# Altium schematic sheet Caja_de_Botones.SchDoc(Caja_de_Botones)
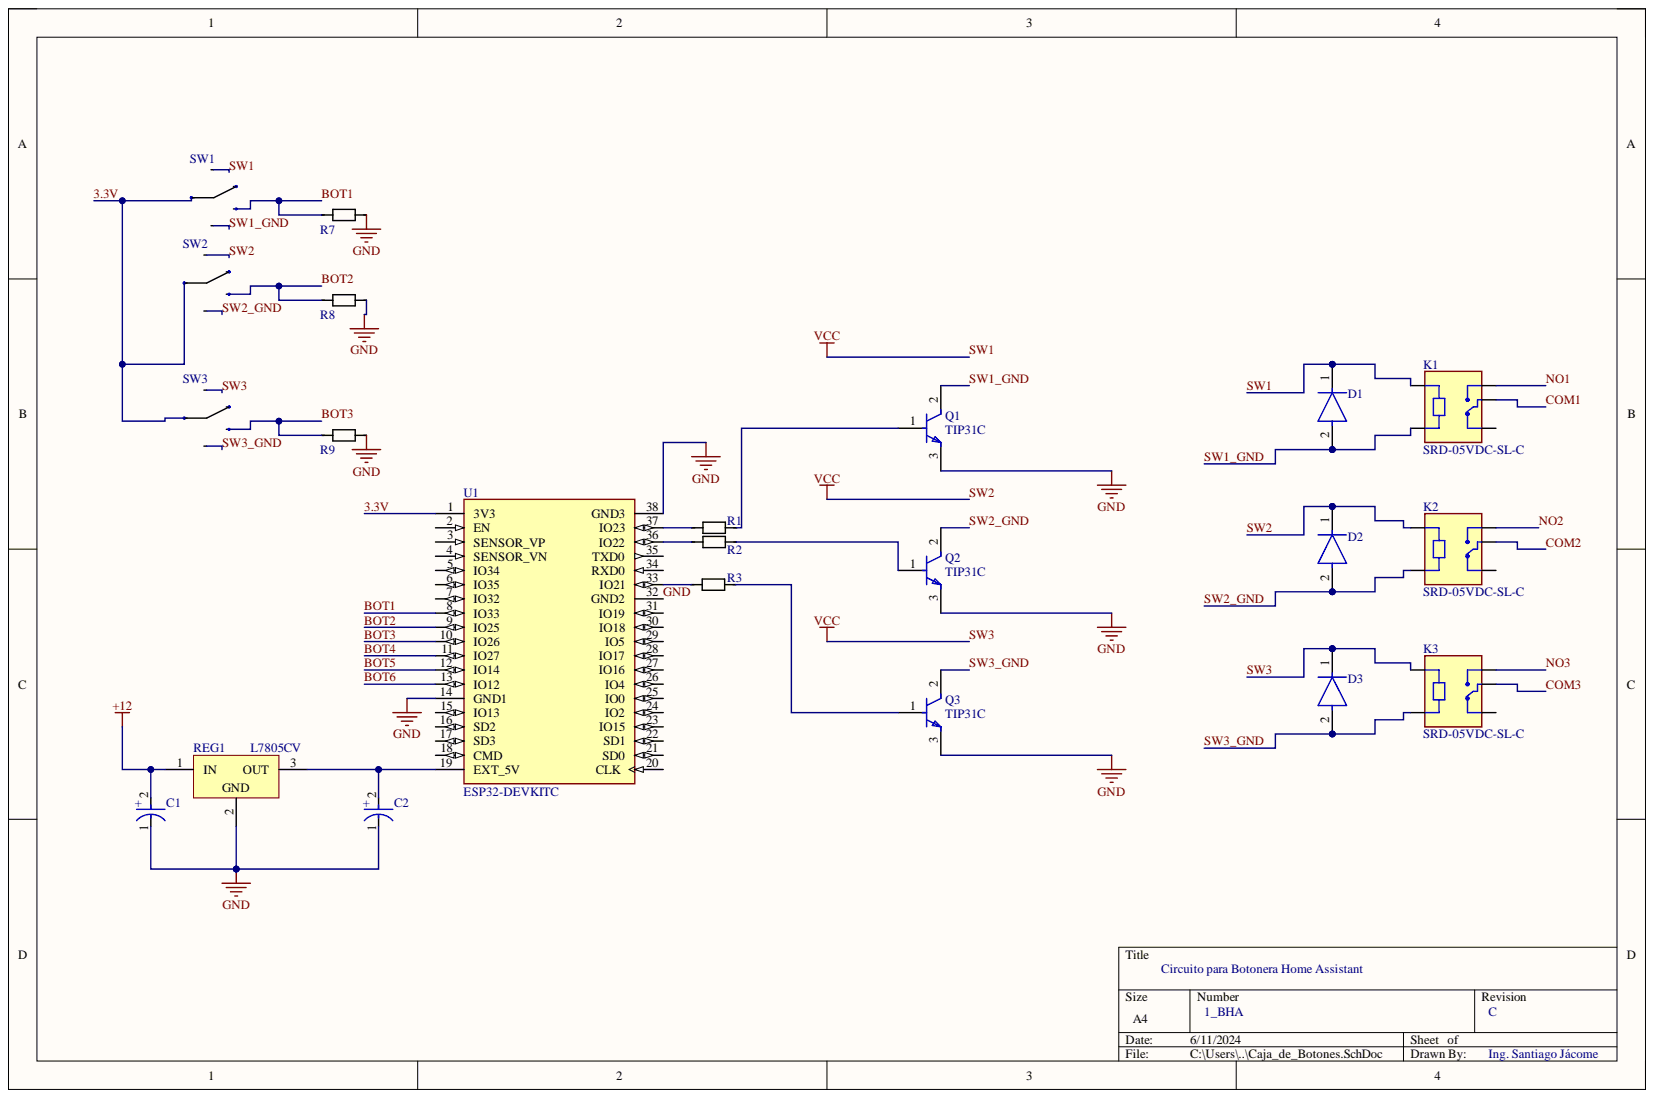
[diagram p0: Caja_de_Botones.SchDoc]

## Parts
C1  EEAGA1V470  [FP-RAD-TH-D_8_0_5-L_7_1-F-MFG]  (value not drawn)
C2  EEAGA1V470  [FP-RAD-TH-D_8_0_5-L_7_1-F-MFG]  (value not drawn)
D1  1N4001-E3/54  [FP-1N4001-E3-54-MFG]  (value not drawn)
D2  1N4001-E3/54  [FP-1N4001-E3-54-MFG]  (value not drawn)
D3  1N4001-E3/54  [FP-1N4001-E3-54-MFG]  (value not drawn)
K1  SRD-05VDC-SL-C  [RELAY_SRD-05VDC-SL-C]
K2  SRD-05VDC-SL-C  [RELAY_SRD-05VDC-SL-C]
K3  SRD-05VDC-SL-C  [RELAY_SRD-05VDC-SL-C]
Q1  TIP31C  [FP-TO-220-P0A0015988-MFG]
Q2  TIP31C  [FP-TO-220-P0A0015988-MFG]
Q3  TIP31C  [FP-TO-220-P0A0015988-MFG]
R1  General 1/4 W Resistor  [Generic 1/4W Metallic Resistor Axial]  (value not drawn)
R2  General 1/4 W Resistor  [Generic 1/4W Metallic Resistor Axial]  (value not drawn)
R3  General 1/4 W Resistor  [Generic 1/4W Metallic Resistor Axial]  (value not drawn)
R7  General 1/4 W Resistor  [Generic 1/4W Metallic Resistor Axial]  (value not drawn)
R8  General 1/4 W Resistor  [Generic 1/4W Metallic Resistor Axial]  (value not drawn)
R9  General 1/4 W Resistor  [Generic 1/4W Metallic Resistor Axial]  (value not drawn)
REG1  L7805CV  [TO220]
SW1  16mm 5 Pin Momentary Button  [16mm Pushbutton 5 Pins]  (value not drawn)
SW2  16mm 5 Pin Momentary Button  [16mm Pushbutton 5 Pins]  (value not drawn)
SW3  16mm 5 Pin Momentary Button  [16mm Pushbutton 5 Pins]  (value not drawn)
U1  ESP32-DEVKITC  [MODULE_ESP32-DEVKITC]

## Nets
+12: C1.2 REG1.1
3.3V: SW1.COM SW2.COM SW3.COM U1.1(3V3)
BOT1: R7.1 SW1.NO U1.8(IO33)
BOT2: R8.1 SW2.NO U1.9(IO25)
BOT3: R9.1 SW3.NO U1.10(IO26)
BOT4: U1.11(IO27)
BOT5: U1.12(IO14)
BOT6: U1.13(IO12)
COM1: K1.COM
COM2: K2.COM
COM3: K3.COM
GND: C1.1 C2.1 Q1.3 Q2.3 Q3.3 R7.2 R8.2 R9.2 REG1.2 U1.14(GND1) U1.32(GND2) U1.38(GND3)
NO1: K1.NO
NO2: K2.NO
NO3: K3.NO
SW1: D1.1 D2.1 D3.1 K1.A1 K2.A1 K3.A1 SW1.LED- SW2.LED- SW3.LED-
SW1_GND: D1.2 K1.A2 Q1.2 SW1.LED+
SW2_GND: D2.2 K2.A2 Q2.2 SW2.LED+
SW3_GND: D3.2 K3.A2 Q3.2 SW3.LED+
NetC2_2: C2.2 REG1.3 U1.19(EXT_5V)
NetQ1_1: Q1.1 R1.2
NetQ2_1: Q2.1 R2.2
NetQ3_1: Q3.1 R3.2
NetR1_1: R1.1 U1.37(IO23)
NetR2_1: R2.1 U1.36(IO22)
NetR3_1: R3.1 U1.33(IO21)

Not connected: K1 NC; K2 NC; K3 NC; SW1 NC; SW2 NC; SW3 NC; U1 2(EN) 3(SENSOR_VP) 4(SENSOR_VN) 5(IO34) 6(IO35) 7(IO32) 15(IO13) 16(SD2) 17(SD3) 18(CMD) 20(CLK) 21(SD0) 22(SD1) 23(IO15) 24(IO2) 25(IO0) 26(IO4) 27(IO16) 28(IO17) 29(IO5) 30(IO18) 31(IO19) 34(RXD0) 35(TXD0)

## Library data of the parts
C1 C2 = CMP-05427-002179-1: Aluminum Electrolytic Capacitors (Radial Lead Type) 47uF ±20% 35V; Lead Diameter: 0.45mm; Lead/Base Style: Straight; Leakage Current: 16.5uA; Life (Hours): 1000Hour; Ripple Current: 96mA; Capacitance: 47uF; Case/Package: Radial; Component Kind: Standard; Construction: RADIAL; Contact Plating: Tin; Diameter: 8mm; Height: 7mm; Height - Seated (Max): 0.276inch; Lead Free: Lead Free; Lead Pitch: 2.5mm; Length: 7mm; Library Name: Altium Content Vault; Max Operating Temperature: 105°C; Min Operating Temperature: -55°C; Mount: Through Hole; Number of Pins: 2; Package Quantity: 200; Packaging: Bulk; Radiation Hardening: No; Reach SVHC Compliant: Yes; Ripple Current (AC): 96mA; RoHS Compliant: Yes; Series: GA; Termination: Radial; Tolerance: 20%; Voltage: 35V; Voltage Rating: 35V; Voltage Rating (DC): 35V
D1-D3 = CMP-02540-000077-1: DIODE GEN PURP 50V 1A DO204AL; Capacitance: 15pF; Element Configuration: Single; Forward Voltage: 1.1V; Max Forward Surge Current (Ifsm): 30A; Max Reverse Current: 5uA; Peak Non-Repetitive Surge Current: 45A; Polarity: Standard; Average Rectified Current: 1A; Case/Package: DO-204AL; Component Kind: Standard; Current: 1A; Current Rating: 1A; Diameter: 2.7mm; Forward Current: 1A; Height: 2.7mm; Lead Free: Lead Free; Length: 0.204inch; Library Name: Altium Content Vault; Max Operating Temperature: 150°C; Max Repetitive Reverse Voltage (Vrrm): 50V; Max Reverse Voltage (DC): 50V; Max Surge Current: 30A; Min Operating Temperature: -50°C; Mount: Through Hole; Number of Pins: 2; Output Current: 1A; Package Quantity: 5500; Packaging: Tape and Reel; Radiation Hardening: No; Reverse Voltage: 50V; RoHS Compliant: Yes; Series: -; Voltage: 50V; Voltage Rating (DC): 50V; Width: 2.7mm
K1-K3 = SRD-05VDC-SL-C: 5V Trigger Relay Module For Arduino And Raspberry Pi 5V Trigger Relay Module For Arduino And Raspberry Pi; MF: Songle Relay; Availability: Not in stock; Check_prices: https://www.snapeda.com/parts/SRD-05VDC-SL-C/Songle+Relay/view-part/?ref=eda; Component Kind: Standard; MP: SRD-05VDC-SL-C; Package: NON STANDARD-5 Songle Relay; Price: None; SnapEDA_Link: https://www.snapeda.com/parts/SRD-05VDC-SL-C/Songle+Relay/view-part/?ref=snap; Library Name: Santiagos_Library.SchLib
Q1-Q3 = CMP-12195-000001-1: TRANS NPN 100V 3A TO-220; Manufacturer: STMicroelectronics; Collector Emitter Saturation Voltage: 1.2V; Emitter Base Voltage: 5V; Hfe Max: 50; Hfe Min: 10; Hfe Testvce: 4V; Max Junction Temp: +150°C; Number Of Elements: 1; Power Dissipation: 2W; Standoff Height: 3.5mm; Automotive: No; Category: Trans; Collector Base Voltage: 100V; Collector Emitter Voltage: 100V; Component Kind: Standard; ComponentLink2Description: Datasheet; ComponentLink2URL: https://www.st.com/resource/en/datasheet/tip31c.pdf; Device Class L1: Discrete Semiconductors; Device Class L2: Transistors; Device Class L3: BJTs; Digikey Description: TRANS NPN 100V 3A TO-220; Height: 19.68mm; Hfe Testic: 3A; Lead Free: Yes; Library Name: Altium Content Vault; Manufacturer Part Number: TIP31C; Max Collector Current: 3A; Mouser Description: Bipolar Transistors - BJT NPN Gen Pur Power; Number Of Npn: 1; Octopart: https://octopart.com/tip31c-stmicroelectronics-15555; Package: TO-220-P0A0015988; RoHS: TRUE; Temperature: to +150°C; Temperature Range High: +150°C
R1-R3 R7-R9 = CMP-009-00323-1: 1% Tolerance, 0.25W; Component Kind: Standard; Design Item ID: General 1/4 W Resistor; Library Name: Altium Certification; Source: Santiagos_Library.SchLib
REG1 = CMP-0244-00483-1: Positive Voltage Regulator, 5V, 3-Pin TO-220; Component Kind: Standard; ComponentLink1Description: Manufacturer URL; ComponentLink1URL: http://www.st.com; ComponentLink2Description: Datasheet; ComponentLink2URL: http://www.st.com/internet/com/TECHNICAL_RESOURCES/TECHNICAL_LITERATURE/DATASHEET/CD00000444.pdf; ComponentLink3Description: Package Specification; ComponentLink3URL: http://www.st.com/internet/com/TECHNICAL_RESOURCES/TECHNICAL_LITERATURE/PACKAGE_INFORMATION/CD00004649.pdf; DatasheetVersion: 16/11/2011; Library Name: Altium Content Vault; PackageDescription: TO, Thru-Hole, Vertical, Heatsink Mounted; 3 In-Line Leads; Pitch 2.54 mm; PackageReference: TO220; PackageVersion: ver3.0
SW1-SW3 = CMP-011-00013-1: 16mm Button with LED indicator.; Component Kind: Standard; Design Item ID: 16mm 5 Pin Momentary Button; Library Name: Altium Certification; Source: Santiagos_Library.SchLib
U1 = ESP32-DEVKITC: ESP32-WROOM-32UE series Transceiver; 802.11 b/g/n (Wi-Fi, WiFi, WLAN), Bluetooth Â® Smart Ready 4.x Dual Mode Evaluation Board; MF: Espressif Systems; Availability: In Stock; Check_prices: https://www.snapeda.com/parts/ESP32-DEVKITC/Espressif+Systems/view-part/?ref=eda; Component Kind: Standard; MP: ESP32-DEVKITC; Package: None; Price: None; Purchase-URL: https://pricing.snapeda.com/search/part/ESP32-DEVKITC/?ref=eda; SnapEDA_Link: https://www.snapeda.com/parts/ESP32-DEVKITC/Espressif+Systems/view-part/?ref=snap; Library Name: Santiagos_Library.SchLib
